# Altium schematic sheet 024-01TFT-SPI7.SchDoc
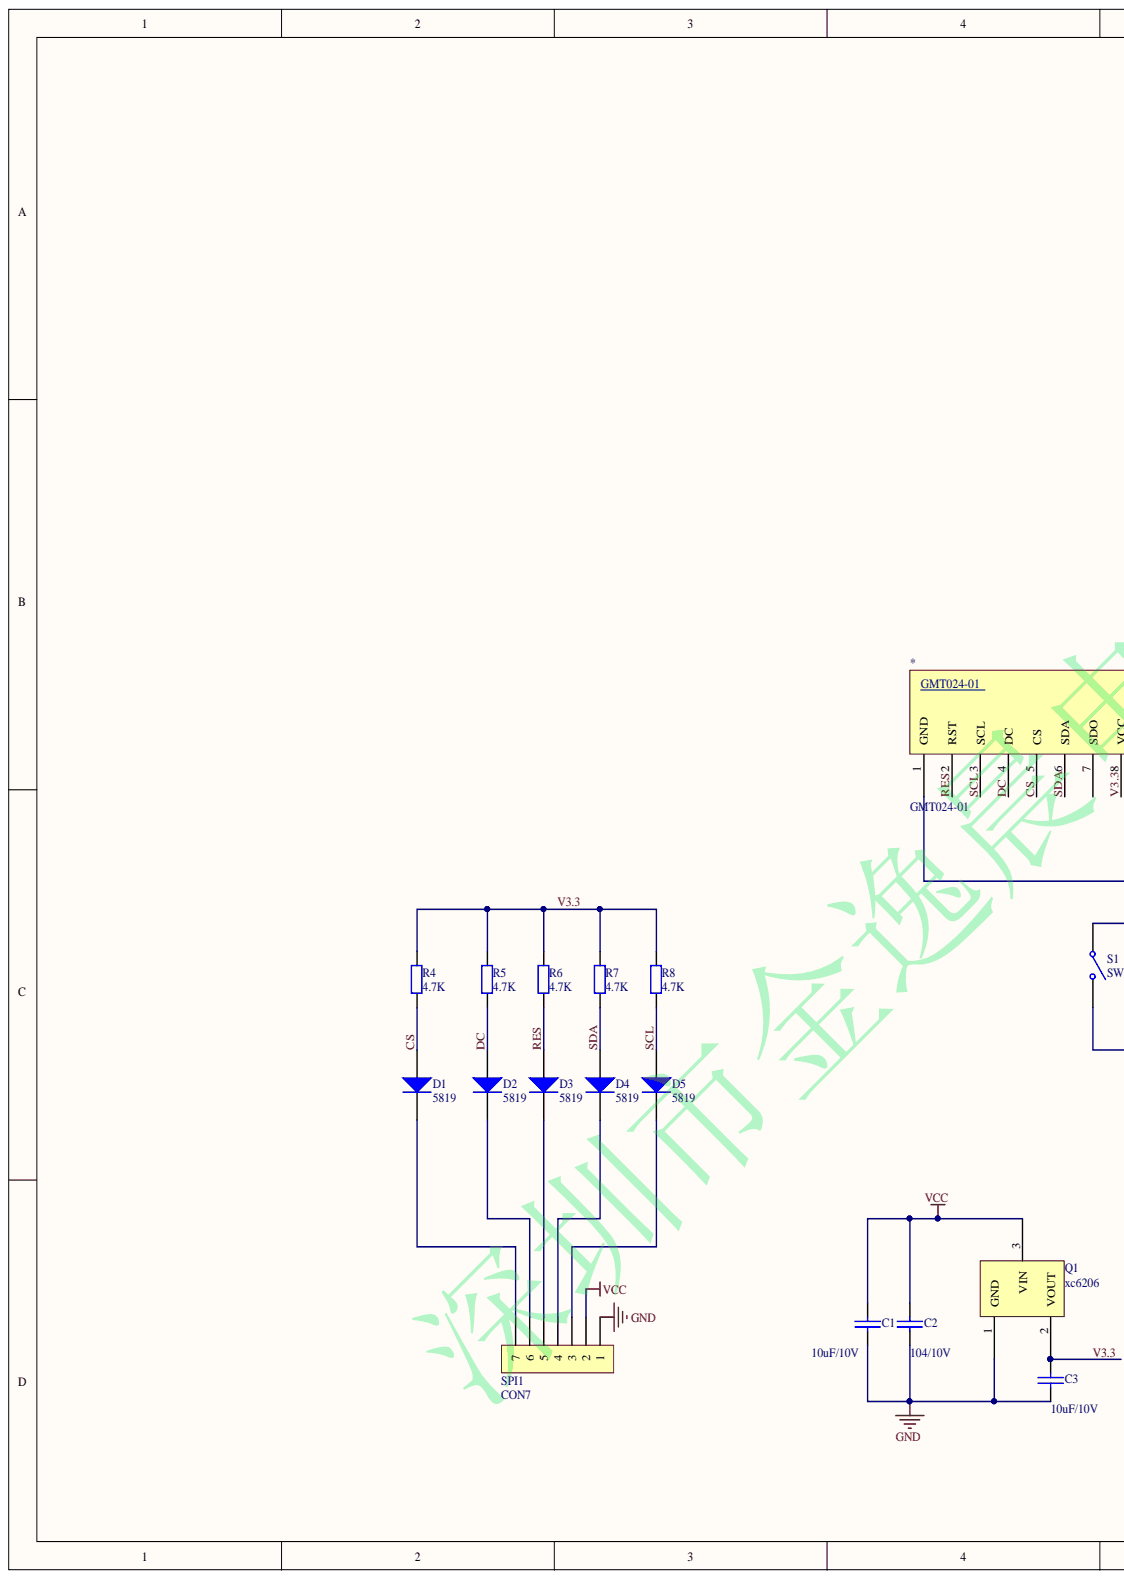
[diagram p0: 024-01TFT-SPI7.SchDoc, left]
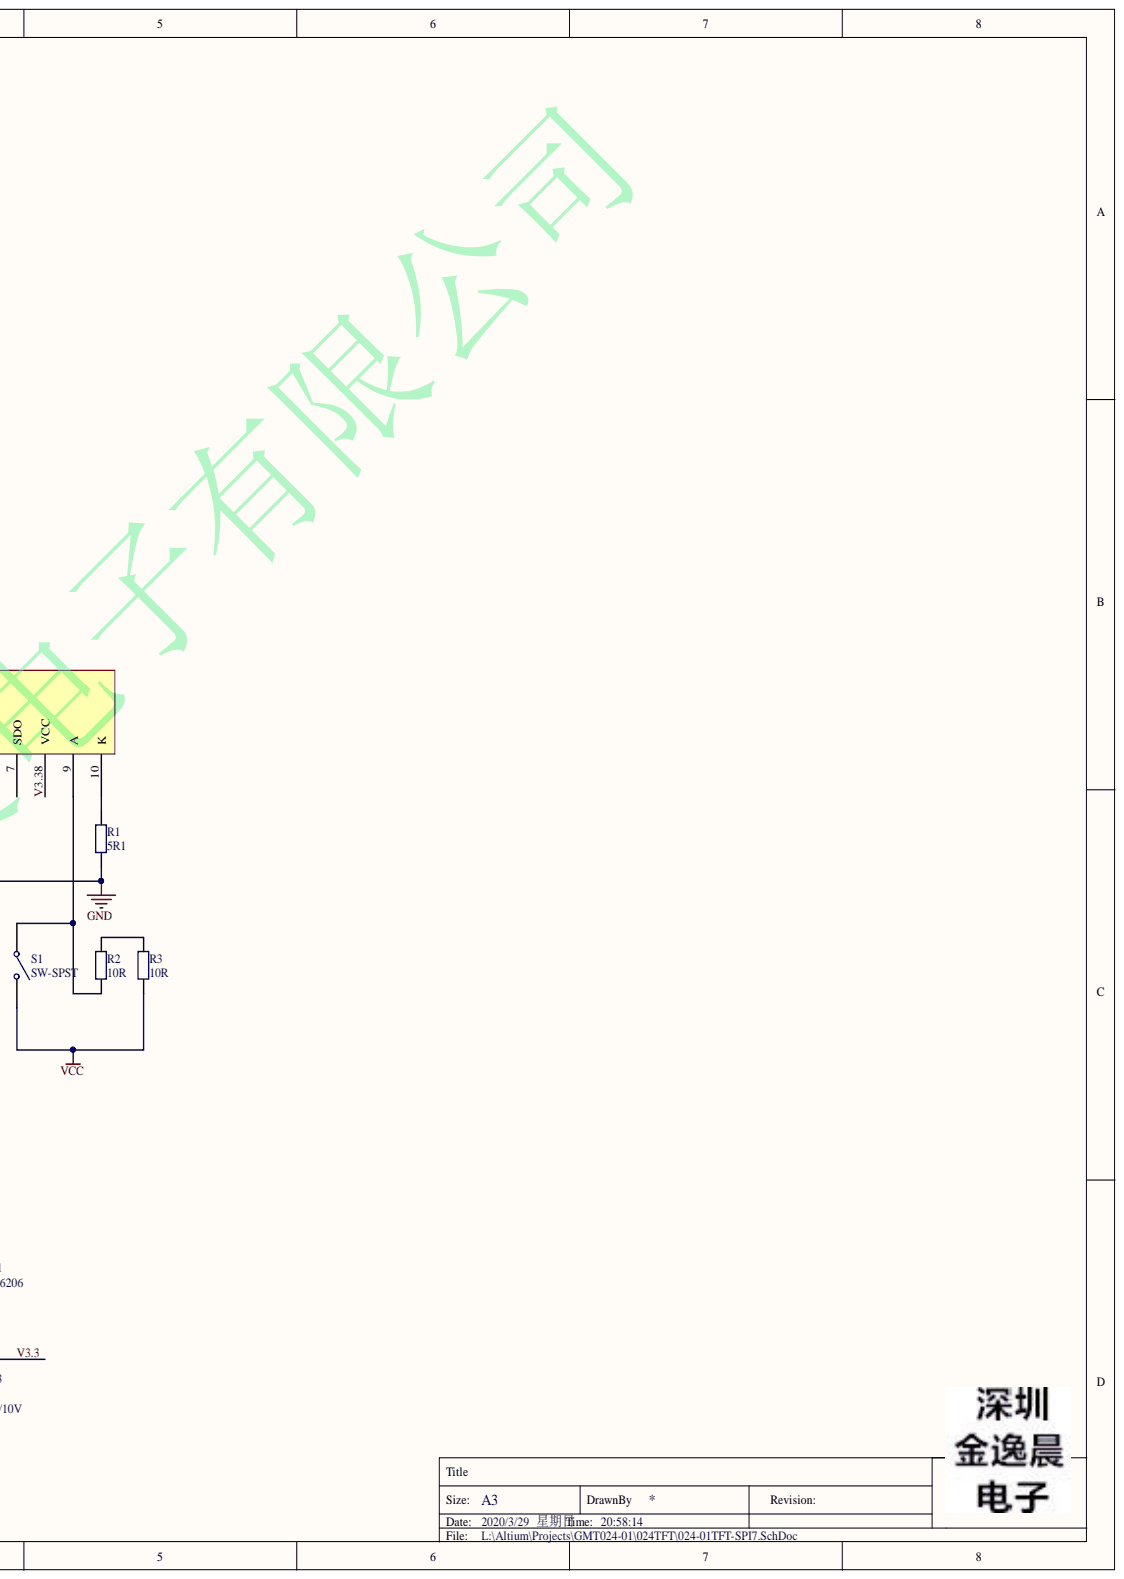
[diagram p1: 024-01TFT-SPI7.SchDoc, right]

## Parts
*  GMT024-01  [GMT024-01]
C1  10uF/10V  [0603]
C2  104/10V  [0603]
C3  10uF/10V  [0603]
D1  5819  [0603_D]
D2  5819  [0603_D]
D3  5819  [0603_D]
D4  5819  [0603_D]
D5  5819  [0603_D]
Q1  xc6206  [SOT-23_N]
R1  5R1  [0603]
R2  10R  [0603]
R3  10R  [0603]
R4  4.7K  [0603]
R5  4.7K  [0603]
R6  4.7K  [0603]
R7  4.7K  [0603]
R8  4.7K  [0603]
S1  SW-SPST  [跳线0.25mm间距]
SPI1  CON7  [HDR1X7]

## Nets
GND: *.1(GND) C1.2 C2.2 C3.2 Q1.1 R1.1 SPI1.1
VCC: C1.1 C2.1 Q1.3 R3.1 S1.2 SPI1.2
CS: *.5 D1.1 R4.1
DC: *.4(电) D2.1 R5.1
RES: *.2(RST) D3.1 R6.1
SCL: *.3(SCL) D5.1 R8.1
SDA: *.6 D4.1 R7.1
V3.3: *.8(VCC) C3.1 Q1.2 R4.2 R5.2 R6.2 R7.2 R8.2
Net*_9: *.9(A) R2.1 S1.1
Net*_10: *.10(K) R1.2
NetD1_2: D1.2 SPI1.7
NetD2_2: D2.2 SPI1.6
NetD3_2: D3.2 SPI1.5
NetD4_2: D4.2 SPI1.4
NetD5_2: D5.2 SPI1.3
NetR2_2: R2.2 R3.2

Not connected: * 7

## Library data of the parts
C1-C3 = CAP: Component Kind: Standard; Library Name: 常用元件库.SCHLIB
D1-D5 = Diode: Default Diode; Code_JEDEC: DO-214-AB; Component Kind: Standard; LatestRevisionDate: 17-Jul-2002; LatestRevisionNote: Re-released for DXP Platform.; Library Name: Miscellaneous Devices.IntLib; PackageDescription: DO-214-AB/SMC; 2 C-Bend Leads; Body 7.9 x 5.9 mm, inc. leads (LxW); PackageReference: SMC; PackageVersion: Sep-1996; Published: 8-Jun-2000; Signal Integrity: Diode; Simulation: DIODE; Publisher: Altium Limited
R1 = Res2: Resistor; Value: 0; Component Kind: Standard; LatestRevisionDate: 17-Jul-2002; LatestRevisionNote: Re-released for DXP Platform.; Library Name: Miscellaneous Devices.IntLib; PackageDescription: Axial Device, Thru-Hole; 2 Leads; 0.4 in Pin Spacing; PackageReference: AXIAL-0.4; Published: 8-Jun-2000; Signal Integrity: Res; Simulation: RESISTOR; Publisher: Altium Limited
R2-R5 R8 = Res2: Resistor; Component Kind: Standard; LatestRevisionDate: 17-Jul-2002; LatestRevisionNote: Re-released for DXP Platform.; Library Name: Miscellaneous Devices.IntLib; PackageDescription: Axial Device, Thru-Hole; 2 Leads; 0.4 in Pin Spacing; PackageReference: AXIAL-0.4; Published: 8-Jun-2000; Signal Integrity: Res; Simulation: RESISTOR; Publisher: Altium Limited
  R2 R3: Value: 10R
  R4 R5 R8: Value: 4.7K
R6 R7 = Res2: Resistor; Value: 10K; Component Kind: Standard; LatestRevisionDate: 17-Jul-2002; LatestRevisionNote: Re-released for DXP Platform.; Library Name: Miscellaneous Devices.IntLib; PackageDescription: Axial Device, Thru-Hole; 2 Leads; 0.4 in Pin Spacing; PackageReference: AXIAL-0.4; Published: 8-Jun-2000; Signal Integrity: Res; Simulation: RESISTOR; Publisher: Altium Limited
S1 = SW-SPST: Single-Pole, Single-Throw Switch; Component Kind: Standard; LatestRevisionDate: 17-Jul-2002; LatestRevisionNote: Re-released for DXP Platform.; Library Name: Miscellaneous Devices.IntLib; PackageDescription: Switch; 2 Leads; PackageReference: SPST-2; Published: 24-Mar-1999; Publisher: Altium Limited
* = GMT024-01: Component Kind: Standard; Library Name: LCD.SchLib
Q1 = xc6206: Component Kind: Standard; Library Name: OLED.SchLib
SPI1 = CON7: Component Kind: Standard; Library Name: 常用元件库.SCHLIB
